# Altium schematic sheet Encoder Fin ARM - System.SchDoc("Encoder Fin ARM - System")
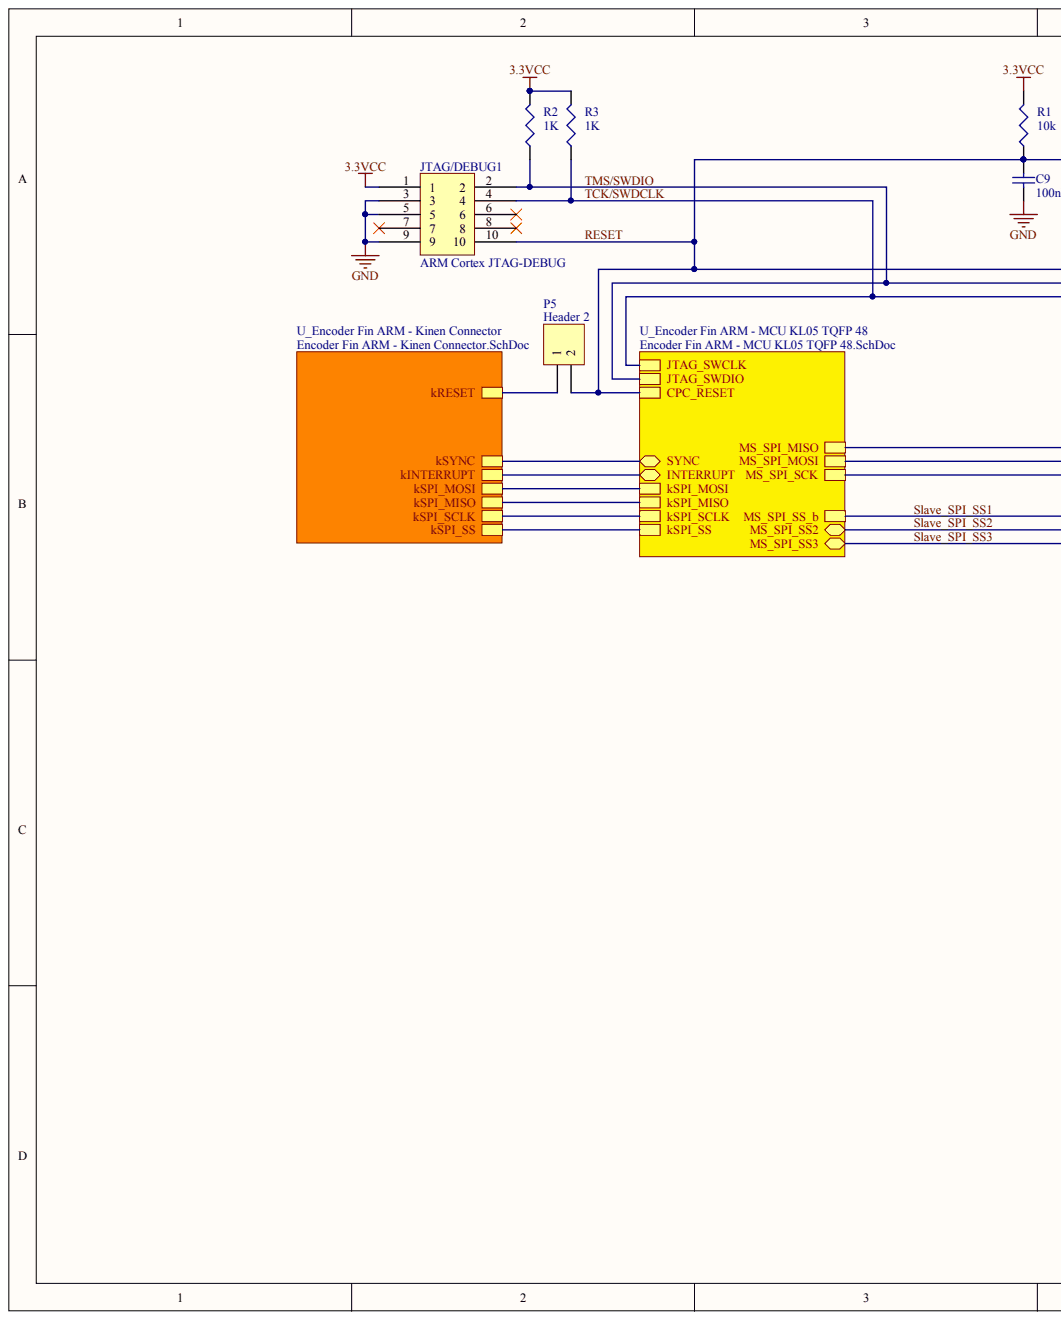
[diagram p0: Encoder Fin ARM - System.SchDoc, left]
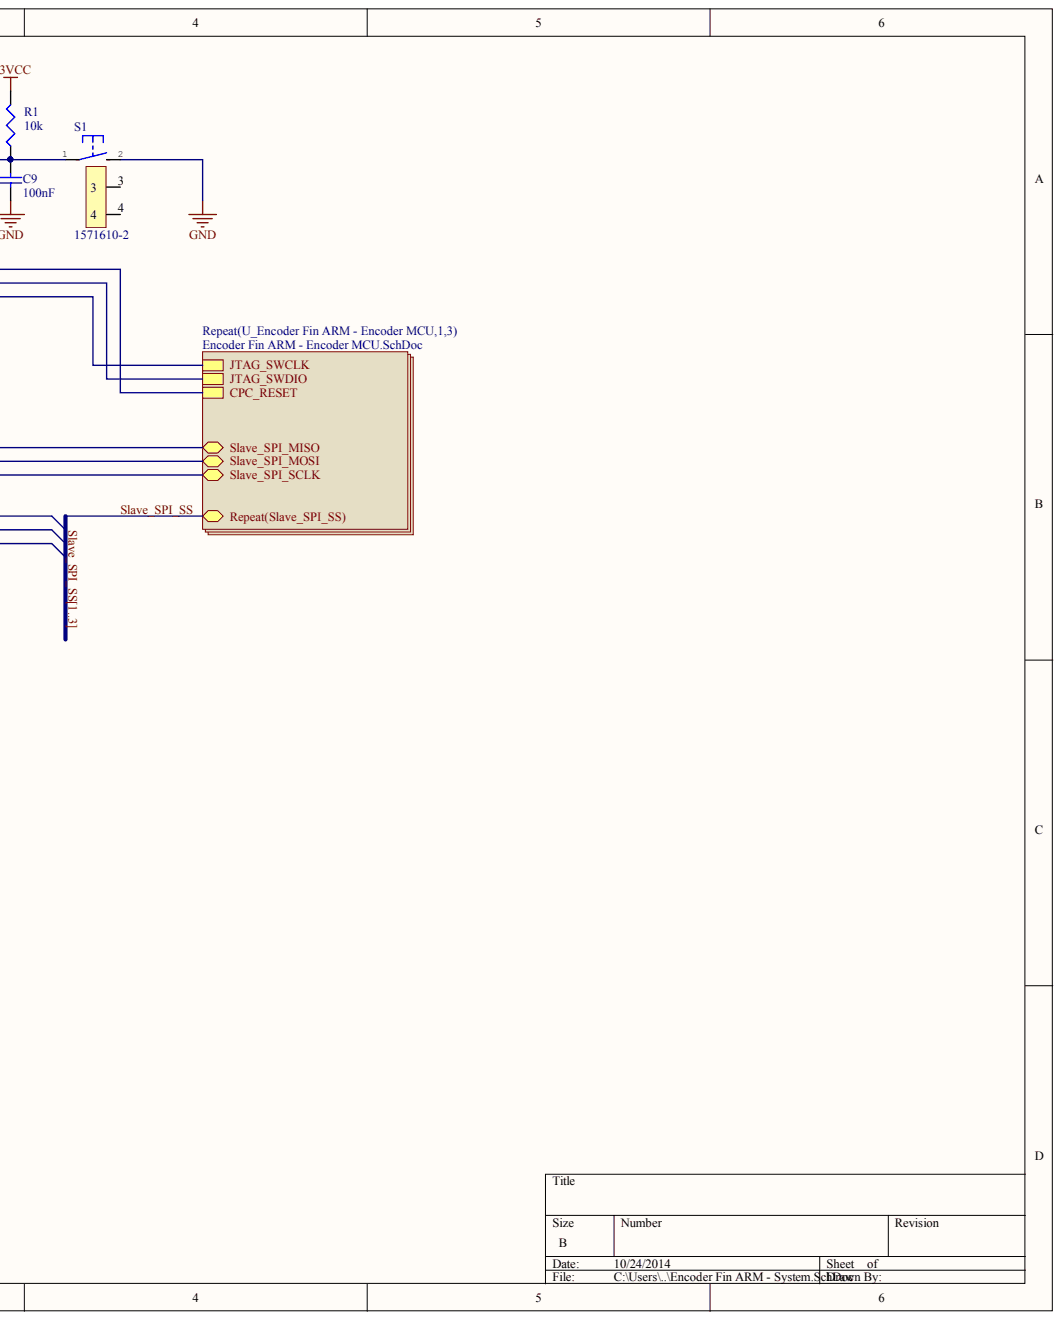
[diagram p1: Encoder Fin ARM - System.SchDoc, right]

## Parts
C9  value 100nF  [1608[0603]]  (hidden comment: Cap Semi)
JTAG/DEBUG1  ARM Cortex JTAG-DEBUG  [FTSH-105-XX-X-DV]
P5  Header 2  [HDR1X2]
R1  value 10k  [J1-0603]  (hidden comment: Res3)
R2  value 1K  [J1-0603]  (hidden comment: Res3)
R3  value 1K  [J1-0603]  (hidden comment: Res3)
S1  1571610-2  [B3F-31XX]

## Nets
3.3VCC: JTAG/DEBUG1.1 R1.2 R2.2 R3.2
GND: C9.1 JTAG/DEBUG1.3 JTAG/DEBUG1.5 JTAG/DEBUG1.9 S1.2
RESET: C9.2 JTAG/DEBUG1.10 P5.2 R1.1 S1.1
TCK/SWDCLK: JTAG/DEBUG1.4 R3.1
TMS/SWDIO: JTAG/DEBUG1.2 R2.1
NetJTAG/DEBUG1_6: JTAG/DEBUG1.6
NetJTAG/DEBUG1_7: JTAG/DEBUG1.7
NetJTAG/DEBUG1_8: JTAG/DEBUG1.8
NetP5_1: P5.1
NetS1_3: S1.3
NetS1_4: S1.4
KINTERRUPT:
KSPI_MISO:
KSPI_MOSI:
KSPI_SCLK:
KSPI_SS:
KSYNC:
SLAVE_SPI_MISO:
SLAVE_SPI_MOSI:
SLAVE_SPI_SCLK:
SLAVE_SPI_SS1:  [also labelled SLAVE_SPI_SS]
SLAVE_SPI_SS2:  [also labelled SLAVE_SPI_SS]
SLAVE_SPI_SS3:  [also labelled SLAVE_SPI_SS]

## Library data of the parts
C9 = Cap Semi: Capacitor (Semiconductor SIM Model); Component Kind: Standard; LatestRevisionDate: 29-May-2009; LatestRevisionNote: IPC-7351 Footprint Added.; Library Name: Miscellaneous Devices.IntLib; PackageDescription: Chip Capacitor; PackageReference: C1206; Published: 8-Jun-2000; Signal Integrity: Cap Semi; Simulation: CAP; Publisher: Altium Limited
JTAG/DEBUG1 = ARM Cortex JTAG-DEBUG Header: Component Kind: Standard; Library Name: Adams Altium Library.SchLib
P5 = Header 2: Header, 2-Pin; Component Kind: Standard; LatestRevisionDate: 17-Jul-2002; LatestRevisionNote: Re-released for DXP Platform.; Library Name: Miscellaneous Connectors.IntLib; Signal Integrity: Connector; Publisher: Altium Limited
R1-R3 = Res3: Resistor; Component Kind: Standard; LatestRevisionDate: 29-May-2009; LatestRevisionNote: IPC-7351 Footprint Added.; Library Name: Miscellaneous Devices.IntLib; PackageDescription: Chip Resistor; PackageReference: J1-0603; Published: 8-Jun-2000; Signal Integrity: Res; Simulation: RESISTOR; Publisher: Altium Limited
S1 = 1571610-2_1: SWTCH Momentary Washable; Manufacturer: Omron; Manufacturer: TE; LastRevisionNote: Import; Component Kind: Standard; ComponentLink1Description: Datasheet for B3F-31XX; ComponentLink1URL: http://www.te.com/commerce/DocumentDelivery/DDEController?Action=srchrtrv&DocNm=1571610&DocType=Customer+Drawing&DocLang=English; LastRevisionDate: 23-Apr-2014; ManufacturerPartNumber: 1571610-2; Published: 23-Apr-2014; Supplier 1: Mouser; Supplier Part Number 1: 506-1571610-2; Library Name: ZMBo Schematic Library.SchLib; Publisher: UltiMachine
